# Altium schematic sheet CGQ2.SchDoc
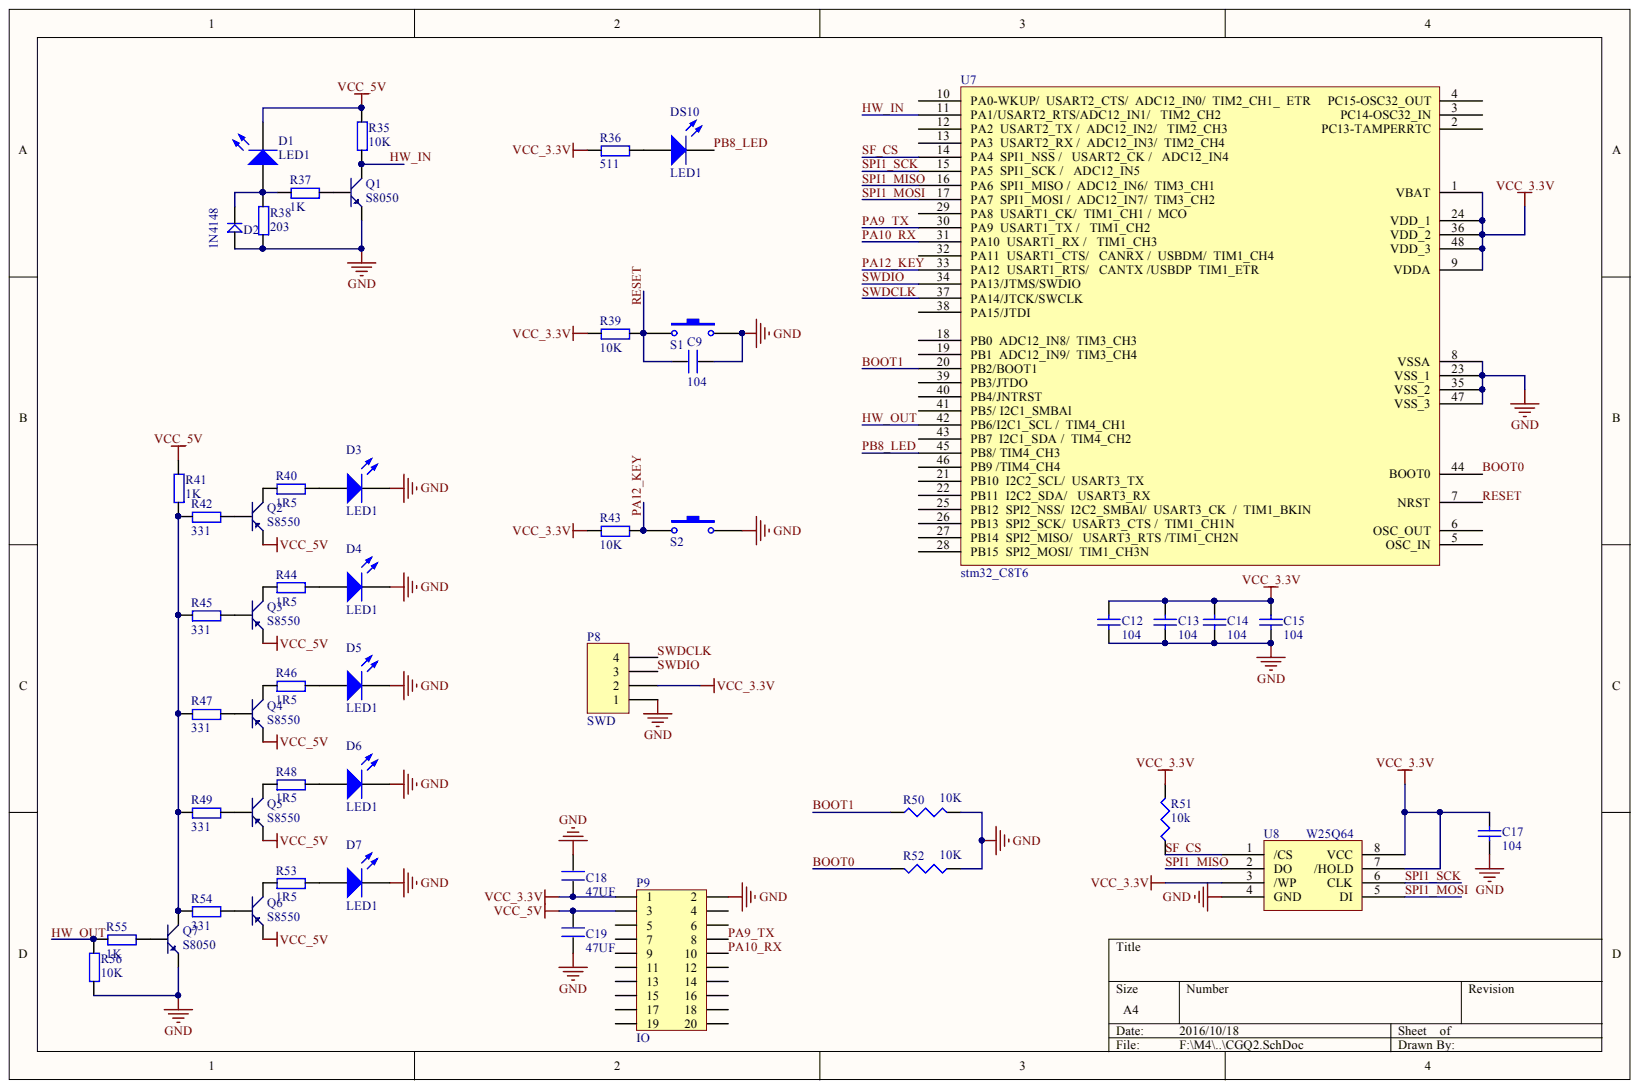
[diagram p0: CGQ2.SchDoc]

## Parts
C9  104  [0603P]
C12  104  [0603P]
C13  104  [0603P]
C14  104  [0603P]
C15  104  [0603P]
C17  104  [0603P]
C18  47UF  [1206]
C19  47UF  [1206]
D1  LED1  [LED_HW__]
D2  1N4148  [DIODE_SOP]
D3  LED1  [LED_HW]
D4  LED1  [LED_HW]
D5  LED1  [LED_HW]
D6  LED1  [LED_HW]
D7  LED1  [LED_HW__]
DS10  LED1  [SMDLED]
P8  SWD  [HDR1X4]
P9  IO  [SMA_P2X10]
Q1  S8050  [SOT-23R]
Q2  S8550  [SOT-23R]
Q3  S8550  [SOT-23R]
Q4  S8550  [SOT-23R]
Q5  S8550  [SOT-23R]
Q6  S8550  [SOT-23R]
Q7  S8050  [SOT-23R]
R35  10K  [0603P]
R36  511  [0603P]
R37  1K  [0603P]
R38  203  [0603P]
R39  10K  [0603P]
R40  1R5  [0603P]
R41  1K  [0603P]
R42  331  [0603P]
R43  10K  [0603P]
R44  1R5  [0603P]
R45  331  [0603P]
R46  1R5  [0603P]
R47  331  [0603P]
R48  1R5  [0603P]
R49  331  [0603P]
R50  10K  [0603P]
R51  10k  [0603P]
R52  10K  [0603P]
R53  1R5  [0603P]
R54  331  [0603P]
R55  1K  [0603P]
R56  10K  [0603P]
S1  SW  [KEY_SMT_2P]  (value not drawn)
S2  SW  [KEY_SMT_2P]  (value not drawn)
U7  stm32_C8T6  [LQFP48]
U8  W25Q64  [SOIC8]

## Nets
GND: C9.2 C12.2 C13.2 C14.2 C15.2 C17.2 C18.2 C19.1 D2.1 D3.2 D4.2 D5.2 D6.2 D7.2 P8.1 P9.2 Q1.1 Q7.1 R38.1 R50.2 R52.1 R56.1 S1.2 S2.2 U7.8(VSSA) U7.23(VSS_1) U7.35(VSS_2) U7.47(VSS_3) U8.4(GND)
VCC_3.3V: C12.1 C13.1 C14.1 C15.1 C17.1 C18.1 P8.2 P9.1 R36.1 R39.1 R43.1 R51.2 U7.1(VBAT) U7.9(VDDA) U7.24(VDD_1) U7.36(VDD_2) U7.48(VDD_3) U8.3(/WP) U8.7(/HOLD) U8.8(VCC)
VCC_5V: C19.2 D1.2 P9.3 Q2.1 Q3.1 Q4.1 Q5.1 Q6.1 R35.2 R41.2
BOOT0: R52.2 U7.44(BOOT0)
BOOT1: R50.1 U7.20(PB2/BOOT1)
HW_IN: Q1.3 R35.1 U7.11(PA1/USART2_RTS/ADC12_IN1/)
HW_OUT: R55.1 R56.2 U7.42(PB6/I2C1_SCL_/)
PA9_TX: P9.8 U7.30(PA9)
PA10_RX: P9.10 U7.31(PA10)
PA12_KEY: R43.2 S2.1 U7.33(PA12)
PB8_LED: DS10.2 U7.45(PB8/_TIM4_CH3)
RESET: C9.1 R39.2 S1.1 U7.7(NRST)
SF_CS: R51.1 U7.14(PA4) U8.1(/CS)
SPI1_MISO: U7.16(PA6) U8.2(DO)
SPI1_MOSI: U7.17(PA7) U8.5(DI)
SPI1_SCK: U7.15(PA5) U8.6(CLK)
SWDCLK: P8.4 U7.37(PA14/JTCK/SWCLK)
SWDIO: P8.3 U7.34(PA13/JTMS/SWDIO)
NetD1_1: D1.1 D2.2 R37.1 R38.2
NetD3_1: D3.1 R40.2
NetD4_1: D4.1 R44.2
NetD5_1: D5.1 R46.2
NetD6_1: D6.1 R48.2
NetD7_1: D7.1 R53.2
NetDS10_1: DS10.1 R36.2
NetQ1_2: Q1.2 R37.2
NetQ2_2: Q2.2 R42.2
NetQ2_3: Q2.3 R40.1
NetQ3_2: Q3.2 R45.2
NetQ3_3: Q3.3 R44.1
NetQ4_2: Q4.2 R47.2
NetQ4_3: Q4.3 R46.1
NetQ5_2: Q5.2 R49.2
NetQ5_3: Q5.3 R48.1
NetQ6_2: Q6.2 R54.2
NetQ6_3: Q6.3 R53.1
NetQ7_2: Q7.2 R55.2
NetQ7_3: Q7.3 R41.1 R42.1 R45.1 R47.1 R49.1 R54.1

Not connected: P9 4 5 6 7 9 11 12 13 14 15 16 17 18 19 20; U7 2(PC13-TAMPERRTC) 3(PC14-OSC32_IN) 4(PC15-OSC32_OUT) 5(OSC_IN) 6(OSC_OUT) 10(PA0-WKUP/) 12(PA2) 13(PA3) 18(PB0) 19(PB1) 21(PB10) 22(PB11) 25(PB12) 26(PB13) 27(PB14) 28(PB15) 29(PA8) 32(PA11) 38(PA15/JTDI) 39(PB3/JTDO) 40(PB4/JNTRST) 41(PB5/_I2C1_SMBAl) 43(PB7) 46(PB9_/TIM4_CH4)

## Library data of the parts
C9 C18 C19 = C: Component Kind: Standard; Library Name: EXPLORER.SCHLIB
C12-C15 C17 = Cap__: Value: 100pF; Component Kind: Standard; LatestRevisionDate: 29-May-2009; LatestRevisionNote: IPC-7351 Footprint Added.; Library Name: Schlib1.SchLib; PackageDescription: Chip Capacitor; PackageReference: C0805; Published: 8-Jun-2000; Publisher: Altium Limited
D1 D3-D7 DS10 = LED2: Typical RED, GREEN, YELLOW, AMBER GaAs LED; Component Kind: Standard; LatestRevisionDate: 17-Jul-2002; LatestRevisionNote: Re-released for DXP Platform.; Library Name: EXPLORER.SCHLIB; PackageDocument: Feb-2002; PackageReference: 3.2X1.6X1.1; Published: 8-Jun-2000; Simulation: LED2; Publisher: Altium Limited
D2 = D: Component Kind: Standard; Library Name: EXPLORER.SCHLIB
P8 = Header 4: Header, 4-Pin; Component Kind: Standard; LatestRevisionDate: 17-Jul-2002; LatestRevisionNote: Re-released for DXP Platform.; Library Name: Miscellaneous Connectors.IntLib; Signal Integrity: Connector; Publisher: Altium Limited
Q1 Q7 = NPN: 8050/BCW846/BCW847; Component Kind: Standard; Library Name: EXPLORER.SCHLIB
Q2-Q6 = PNP: 8550/BCW68; Component Kind: Standard; Library Name: EXPLORER.SCHLIB
R35-R49 R53-R56 = R: Component Kind: Standard; Library Name: EXPLORER.SCHLIB
R50-R52 = Res1: Resistor; Value: 1K; Component Kind: Standard; LatestRevisionDate: 29-May-2009; LatestRevisionNote: IPC-7351 Footprint Added.; Library Name: Schlib1.SchLib; PackageDescription: Chip Resistor; PackageReference: J1-0603; Published: 8-Jun-2000; Publisher: Altium Limited
S1 S2 = Button: Component Kind: Standard; Library Name: MyLibrary.SchLib
U8 = W25Q80: Component Kind: Standard
P9 = IO: Component Kind: Standard; Library Name: zgb.SchLib
U7 = stm32_C8T6: Component Kind: Standard; Library Name: Schlib1.SchLib
